# Altium schematic sheet 0 nas-craftsman nixie MAIN BASE v1.SchDoc
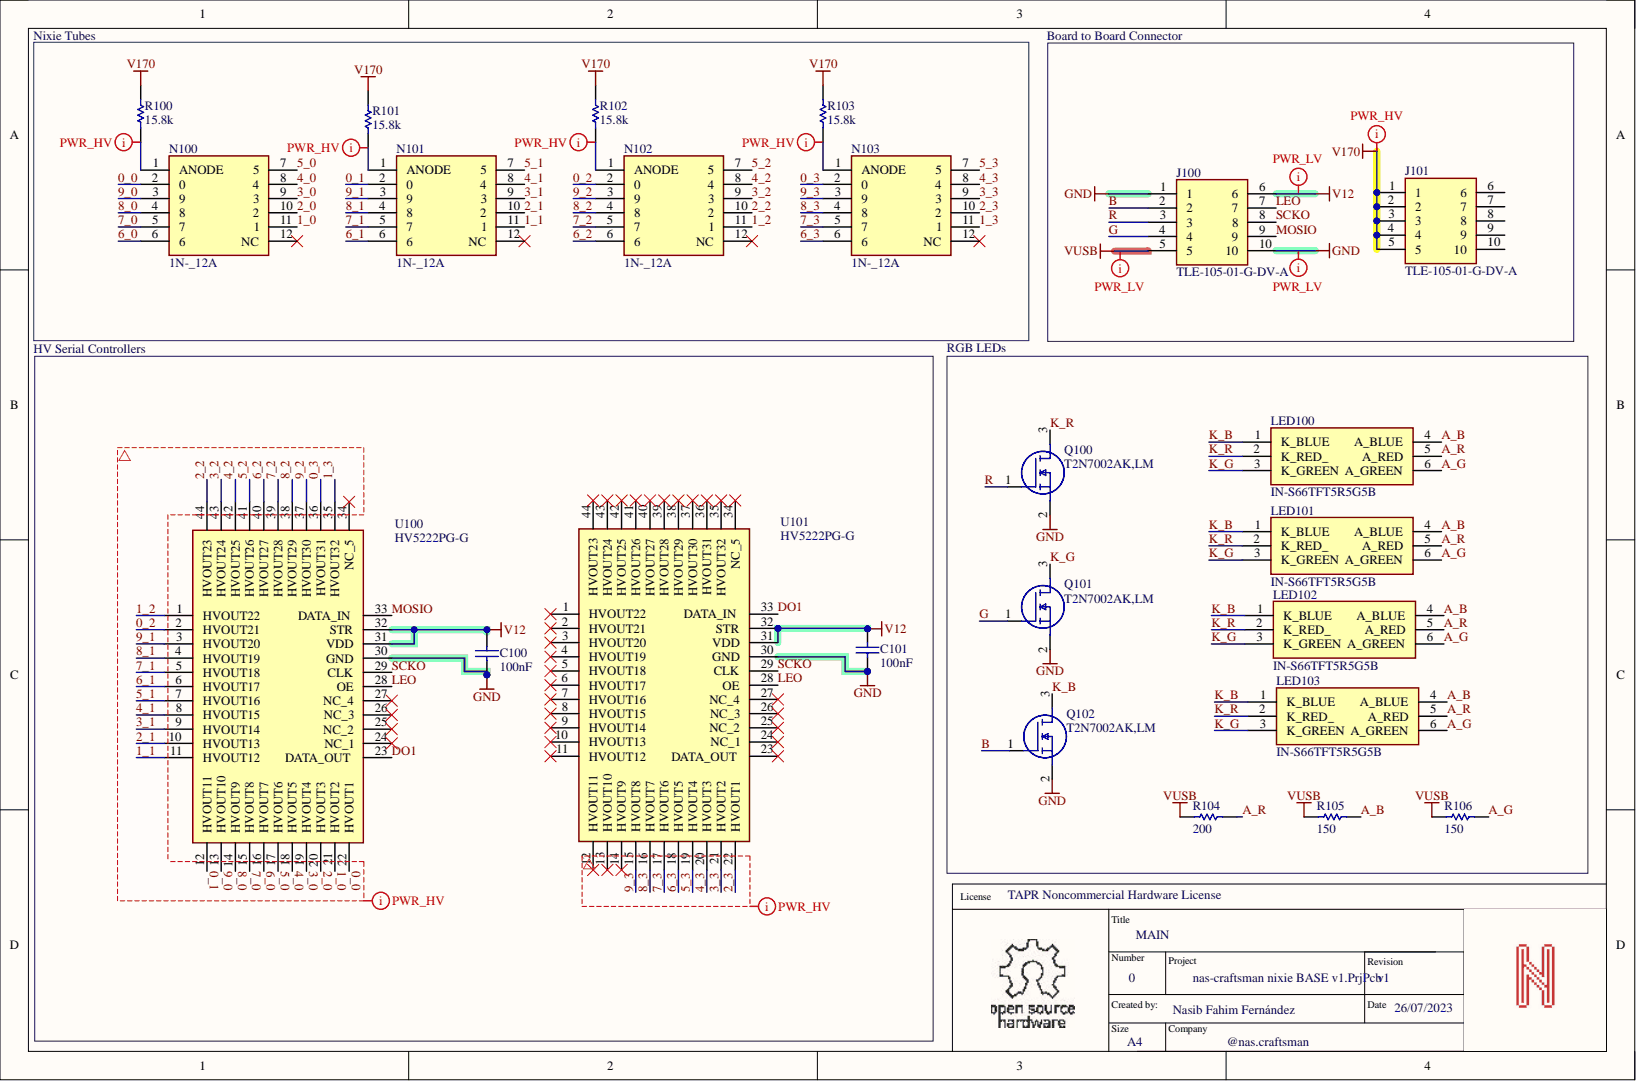
[diagram p0: 0 nas-craftsman nixie MAIN BASE v1.SchDoc]

## Parts
C100  100nF  [CAPC1005X55X25LL5T10]
C101  100nF  [CAPC1005X55X25LL5T10]
J100  TLE-105-01-G-DV-A  [TLE10501GDVA]
J101  TLE-105-01-G-DV-A  [TLE10501GDVA]
LED100  IN-S66TFT5R5G5B  [INS66TFT5R5G5B]
LED101  IN-S66TFT5R5G5B  [INS66TFT5R5G5B]
LED102  IN-S66TFT5R5G5B  [INS66TFT5R5G5B]
LED103  IN-S66TFT5R5G5B  [INS66TFT5R5G5B]
N100  1N-_12A  [1N-12A]
N101  1N-_12A  [1N-12A]
N102  1N-_12A  [1N-12A]
N103  1N-_12A  [1N-12A]
Q100  T2N7002AK,LM  [TBAT54CLM]
Q101  T2N7002AK,LM  [TBAT54CLM]
Q102  T2N7002AK,LM  [TBAT54CLM]
R100  15.8k  [RESC2013X70X40NL20T20]
R101  15.8k  [RESC2013X70X40NL20T20]
R102  15.8k  [RESC2013X70X40NL20T20]
R103  15.8k  [RESC2013X70X40NL20T20]
R104  200  [RESC1005X40X25LL05T05]
R105  150  [RESC1005X40X25LL05T05]
R106  150  [RESC1005X40X25LL05T05]
U100  HV5222PG-G  [QFP80P1390X1390X235-44N]
U101  HV5222PG-G  [QFP80P1390X1390X235-44N]

## Nets
GND: C100.1 C101.1 J100.1 J100.10 Q100.2 Q101.2 Q102.2 U100.30(GND) U101.30(GND)
V12: C100.2 C101.2 J100.6 U100.31(VDD) U100.32(STR) U101.31(VDD) U101.32(STR)
V170: J101.1 J101.2 J101.3 J101.4 J101.5 R100.2 R101.2 R102.2 R103.2
VUSB: J100.5 R104.2 R105.2 R106.2
0_0: N100.2 U100.22(HVOUT1)
0_1: N101.2 U100.12(HVOUT11)
0_2: N102.2 U100.2(HVOUT21)
0_3: N103.2 U100.36(HVOUT31)
1_0: N100.11 U100.21(HVOUT2)
1_1: N101.11 U100.11(HVOUT12)
1_2: N102.11 U100.1(HVOUT22)
1_3: N103.11 U100.35(HVOUT32)
2_0: N100.10 U100.20(HVOUT3)
2_1: N101.10 U100.10(HVOUT13)
2_2: N102.10 U100.44(HVOUT23)
2_3: N103.10 U101.22(HVOUT1)
3_0: N100.9 U100.19(HVOUT4)
3_1: N101.9 U100.9(HVOUT14)
3_2: N102.9 U100.43(HVOUT24)
3_3: N103.9 U101.21(HVOUT2)
4_0: N100.8 U100.18(HVOUT5)
4_1: N101.8 U100.8(HVOUT15)
4_2: N102.8 U100.42(HVOUT25)
4_3: N103.8 U101.20(HVOUT3)
5_0: N100.7 U100.17(HVOUT6)
5_1: N101.7 U100.7(HVOUT16)
5_2: N102.7 U100.41(HVOUT26)
5_3: N103.7 U101.19(HVOUT4)
6_0: N100.6 U100.16(HVOUT7)
6_1: N101.6 U100.6(HVOUT17)
6_2: N102.6 U100.40(HVOUT27)
6_3: N103.6 U101.18(HVOUT5)
7_0: N100.5 U100.15(HVOUT8)
7_1: N101.5 U100.5(HVOUT18)
7_2: N102.5 U100.39(HVOUT28)
7_3: N103.5 U101.17(HVOUT6)
8_0: N100.4 U100.14(HVOUT9)
8_1: N101.4 U100.4(HVOUT19)
8_2: N102.4 U100.38(HVOUT29)
8_3: N103.4 U101.16(HVOUT7)
9_0: N100.3 U100.13(HVOUT10)
9_1: N101.3 U100.3(HVOUT20)
9_2: N102.3 U100.37(HVOUT30)
9_3: N103.3 U101.15(HVOUT8)
A_B: LED100.4(A_BLUE) LED101.4(A_BLUE) LED102.4(A_BLUE) LED103.4(A_BLUE) R105.1
A_G: LED100.6(A_GREEN) LED101.6(A_GREEN) LED102.6(A_GREEN) LED103.6(A_GREEN) R106.1
A_R: LED100.5(A_RED) LED101.5(A_RED) LED102.5(A_RED) LED103.5(A_RED) R104.1
B: J100.2 Q102.1
DO1: U100.23(DATA_OUT) U101.33(DATA_IN)
G: J100.4 Q101.1
K_B: LED100.1(K_BLUE) LED101.1(K_BLUE) LED102.1(K_BLUE) LED103.1(K_BLUE) Q102.3
K_G: LED100.3(K_GREEN) LED101.3(K_GREEN) LED102.3(K_GREEN) LED103.3(K_GREEN) Q101.3
K_R: LED100.2(K_RED_) LED101.2(K_RED_) LED102.2(K_RED_) LED103.2(K_RED_) Q100.3
LEO: J100.7 U100.28(OE) U101.28(OE)
MOSIO: J100.9 U100.33(DATA_IN)
R: J100.3 Q100.1
SCKO: J100.8 U100.29(CLK) U101.29(CLK)
NetN100_1: N100.1(ANODE) R100.1
NetN101_1: N101.1(ANODE) R101.1
NetN102_1: N102.1(ANODE) R102.1
NetN103_1: N103.1(ANODE) R103.1

Not connected: J101 6 7 8 9 10; N100 12(NC); N101 12(NC); N102 12(NC); N103 12(NC); U100 24(NC_1) 25(NC_2) 26(NC_3) 27(NC_4) 34(NC_5); U101 1(HVOUT22) 2(HVOUT21) 3(HVOUT20) 4(HVOUT19) 5(HVOUT18) 6(HVOUT17) 7(HVOUT16) 8(HVOUT15) 9(HVOUT14) 10(HVOUT13) 11(HVOUT12) 12(HVOUT11) 13(HVOUT10) 14(HVOUT9) 23(DATA_OUT) 24(NC_1) 25(NC_2) 26(NC_3) 27(NC_4) 34(NC_5) 35(HVOUT32) 36(HVOUT31) 37(HVOUT30) 38(HVOUT29) 39(HVOUT28) 40(HVOUT27) 41(HVOUT26) 42(HVOUT25) 43(HVOUT24) 44(HVOUT23)

## Library data of the parts
C100 C101 = CMP-1662-00017-1: CAP, MLCC, 0402/1005, 25V, X7R,; Manufacturer: Murata; Part Number: GRM155R71E104KE14D; Component Kind: Standard; Library Name: Altium Content Vault; Value: 100nF
J100 J101 = TLE-105-01-G-DV-A: Connector; Manufacturer: SAMTEC; Arrow Part Number: TLE-105-01-G-DV-A; Arrow Price/Stock: https://www.arrow.com/en/products/tle-105-01-g-dv-a/samtec?region=nac; Component Kind: Standard; Datasheet Link: https://www.mouser.com/datasheet/2/527/tle-1342889.pdf; Height: 4.496mm; Mouser Part Number: 200-TLE10501GDVA; Mouser Price/Stock: https://www.mouser.co.uk/ProductDetail/Samtec/TLE-105-01-G-DV-A?qs=0lQeLiL1qyYapGMpoLj2Zw%3D%3D; Part Number: TLE-105-01-G-DV-A
LED100-LED103 = IN-S66TFT5R5G5B: LED; Manufacturer: Inolux; Arrow Part Number: IN-S66TFT5R5G5B; Arrow Price/Stock: https://www.arrow.com/en/products/in-s66tft5r5g5b/inolux-corporation?region=nac; Component Kind: Standard; Datasheet Link: https://www.mouser.in/datasheet/2/180/IN_S66TFT5R5G5B_V1_0-2258050.pdf; Height: 0.5mm; Mouser Part Number: 743-IN-S66TFT5R5G5B; Mouser Price/Stock: https://www.mouser.co.uk/ProductDetail/Inolux/IN-S66TFT5R5G5B?qs=stqOd1AaK7%2FLVY5moRrQGg%3D%3D; Part Number: IN-S66TFT5R5G5B
N100-N103 = 1N-_12A: Undefined or Miscellaneous; Manufacturer: Reflector/Sovtec; Part Number: 1N- 12A; Component Kind: Standard; Datasheet Link: www.tube-tester.com/sites/nixie/data/1n-12a.html; DNP: DNP; Library Name: SamacSys.SchLib
Q100-Q102 = T2N7002AK,LM: MOSFET (N-Channel); Manufacturer: Toshiba; Arrow Part Number: T2N7002AK,LM; Arrow Price/Stock: https://www.arrow.com/en/products/t2n7002aklm/toshiba?region=nac; Component Kind: Standard; Datasheet Link: http://toshiba.semicon-storage.com/info/docget.jsp?did=29712&prodName=T2N7002AK; Height: 1.1mm; Library Name: SamacSys.SchLib; Mouser Part Number: 757-T2N7002AKLM; Mouser Price/Stock: https://www.mouser.co.uk/ProductDetail/Toshiba/T2N7002AKLM?qs=pzVvXTvk9PzfX4hYglwZ9Q%3D%3D; Part Number: T2N7002AK,LM
R100-R103 = CMP-1665-00002-5: SMD 1/4W 15.8K OHM 1%; Manufacturer: KOA Speer; Part Number: RK73H2ATTD1582F; Component Kind: Standard; ComponentLink1Description: Manufacturer URL; ComponentLink1URL: http://www.panasonic.com/; ComponentLink2Description: Datasheet; ComponentLink2URL: http://industrial.panasonic.com/cdbs/www-data/pdf/RDA0000/AOA0000C84.pdf; Library Name: Altium Content Vault; Value: 15.8k
R104 = CMP-2002-08382-1: SMD 200 Ohms 62.5 mW 0402 1%; Manufacturer: Yageo; Part Number: RE0402FRE07200RL; Component Kind: Standard; Library Name: Altium Content Vault; Value: 200
R105 R106 = CMP-2002-08382-1: SMD 150 Ohms 62.5mW 0402 1%; Manufacturer: Yageo; Part Number: RC0402FR-07150RL; Component Kind: Standard; Library Name: Altium Content Vault; Value: 150
U100 U101 = HV5222PG-G: HV OD Outs Serial to Parallel Converter; Manufacturer: Microchip; Arrow Part Number: HV5222PG-G; Arrow Price/Stock: https://www.arrow.com/en/products/hv5222pg-g/microchip-technology; Component Kind: Standard; Datasheet Link: http://www.microchip.com/mymicrochip/filehandler.aspx?ddocname=en570722; Height: 2.35mm; Mouser Part Number: 689-HV5222PG-G; Mouser Price/Stock: https://www.mouser.co.uk/ProductDetail/Microchip-Technology/HV5222PG-G?qs=jjdktuRV%2Fgh6FkXzyQ9ehg%3D%3D; Part Number: HV5222PG-G
